# Altium schematic sheet habitcal_front_0.SchDoc
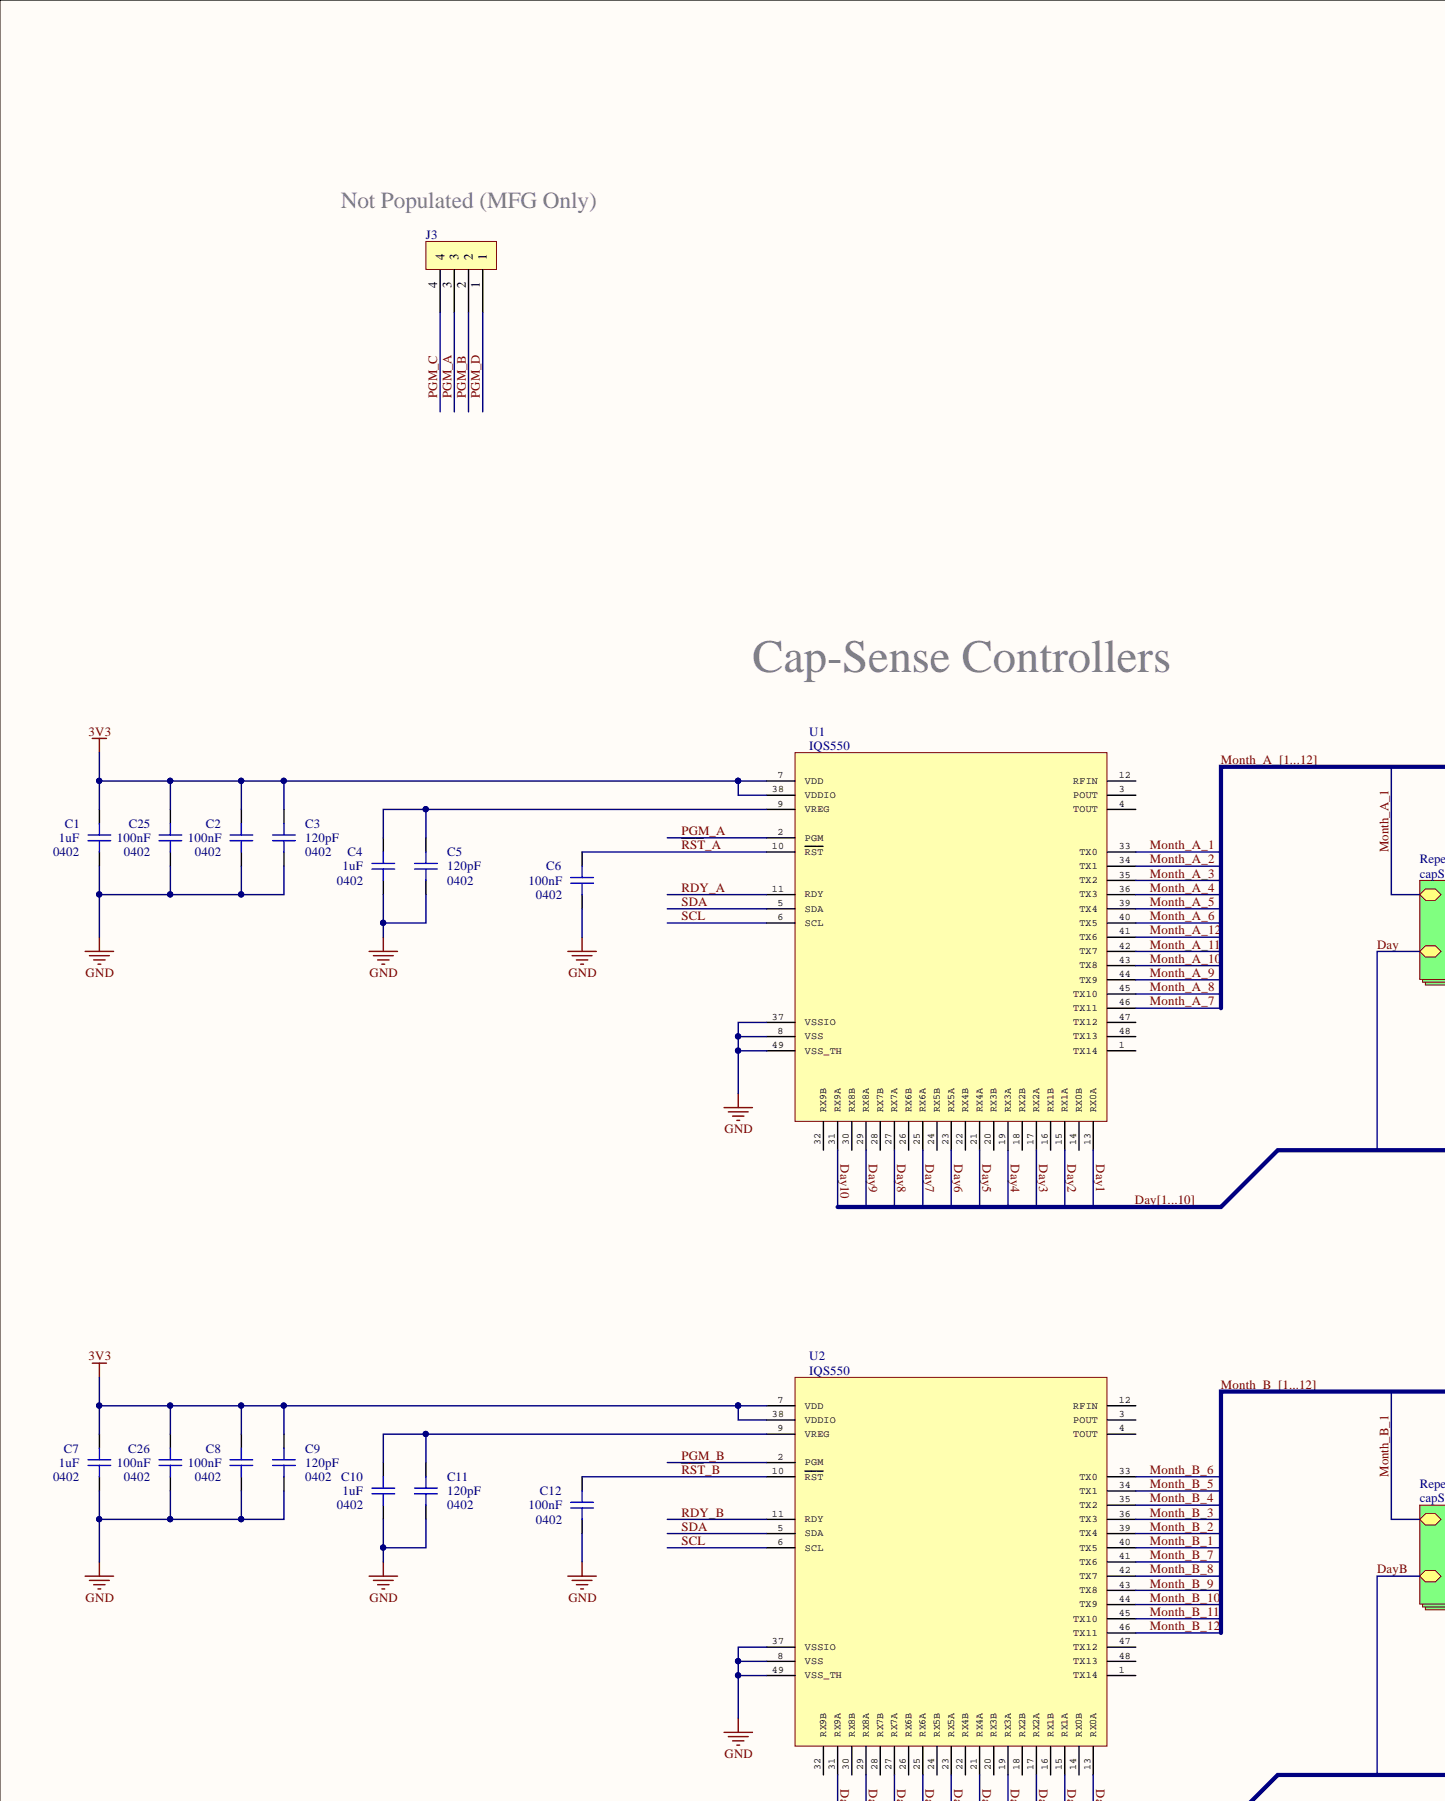
[diagram p0: habitcal_front_0.SchDoc, top left]
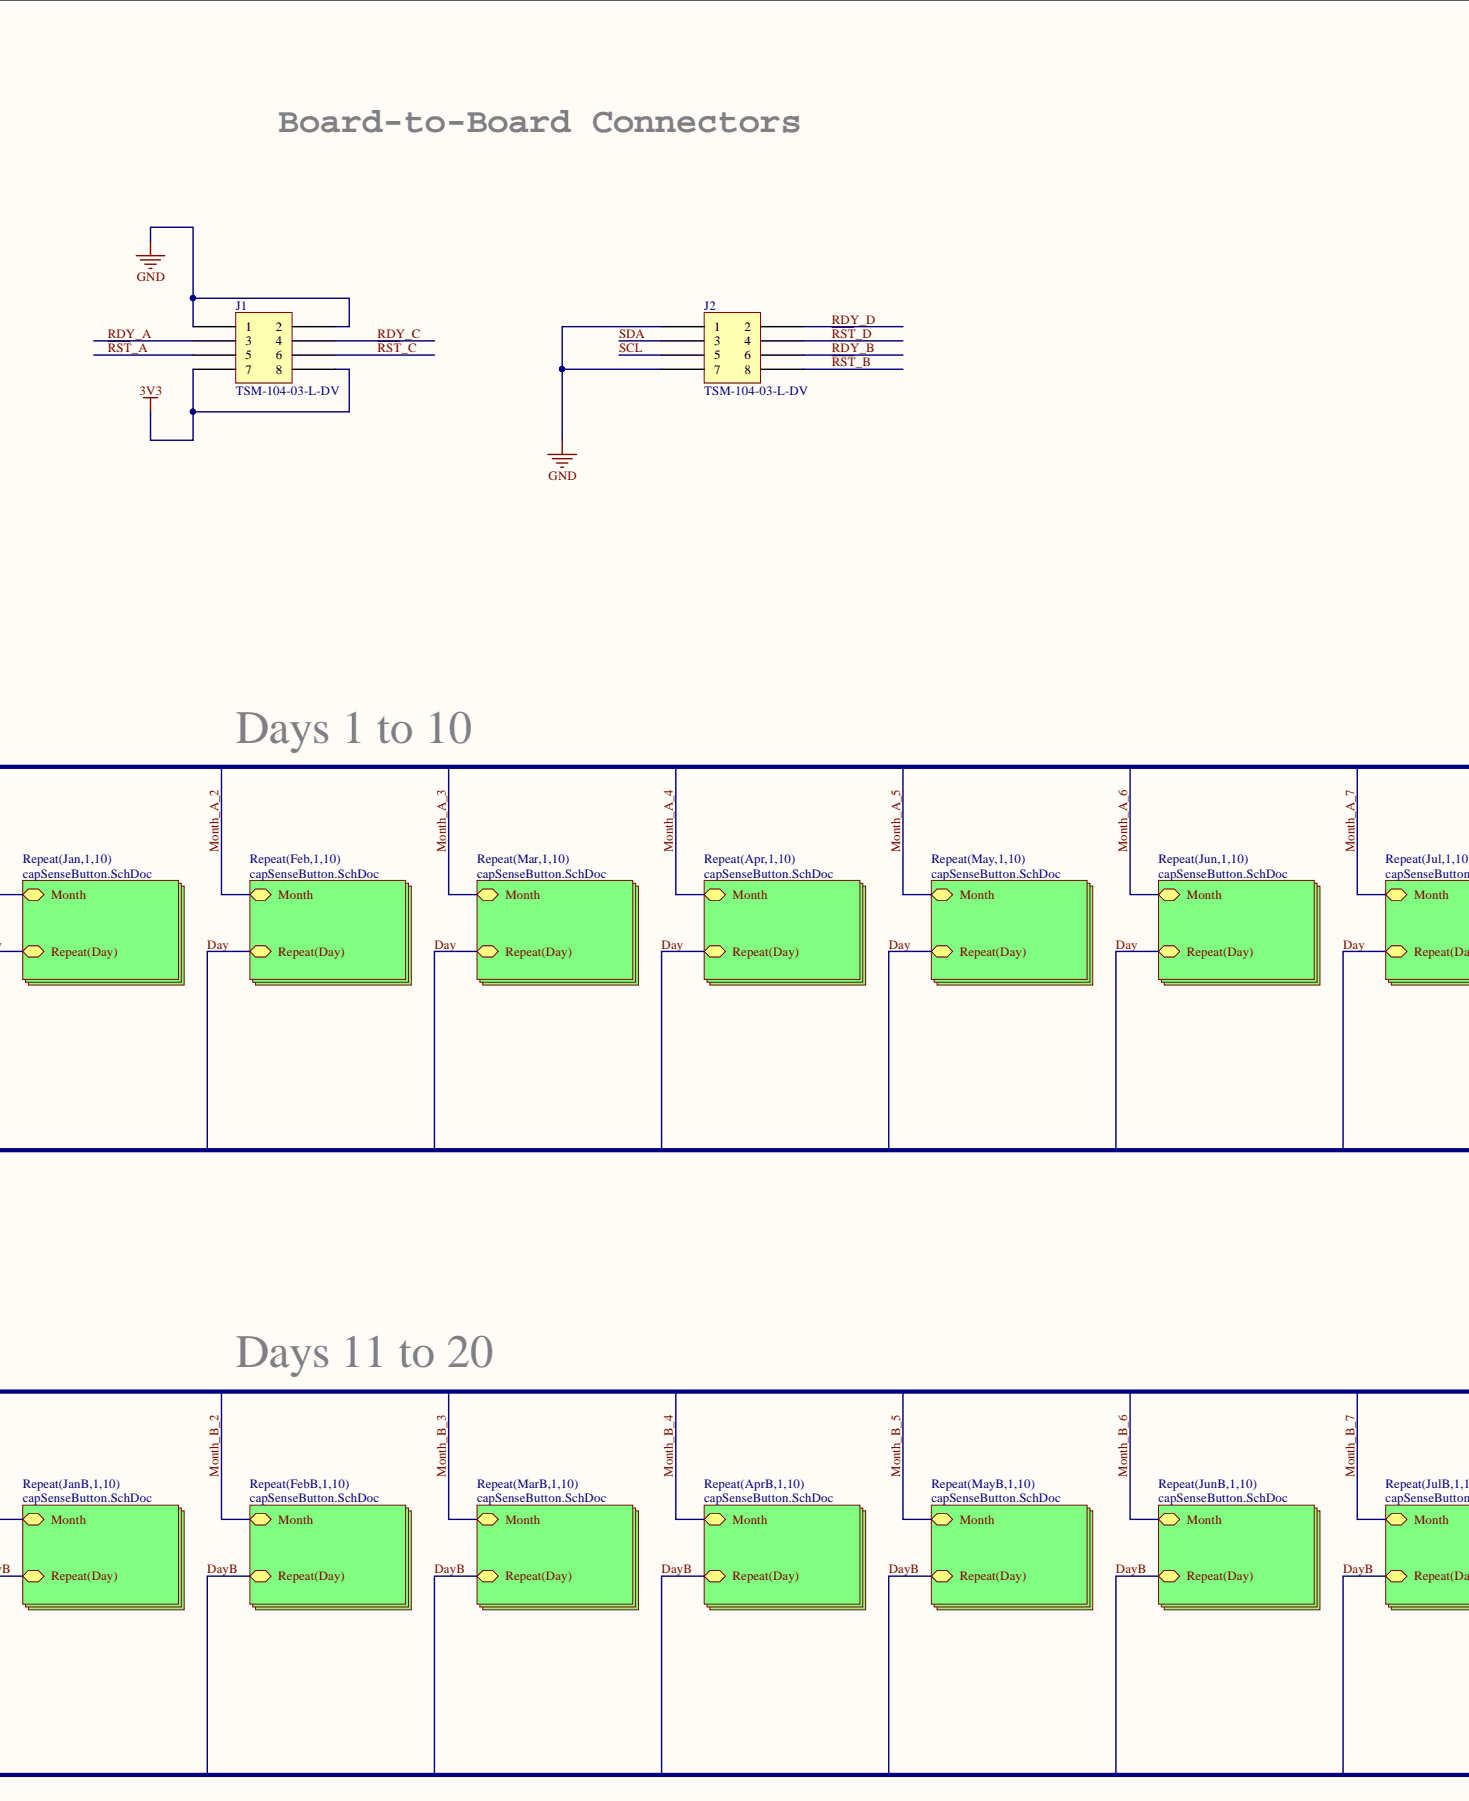
[diagram p1: habitcal_front_0.SchDoc, top centre]
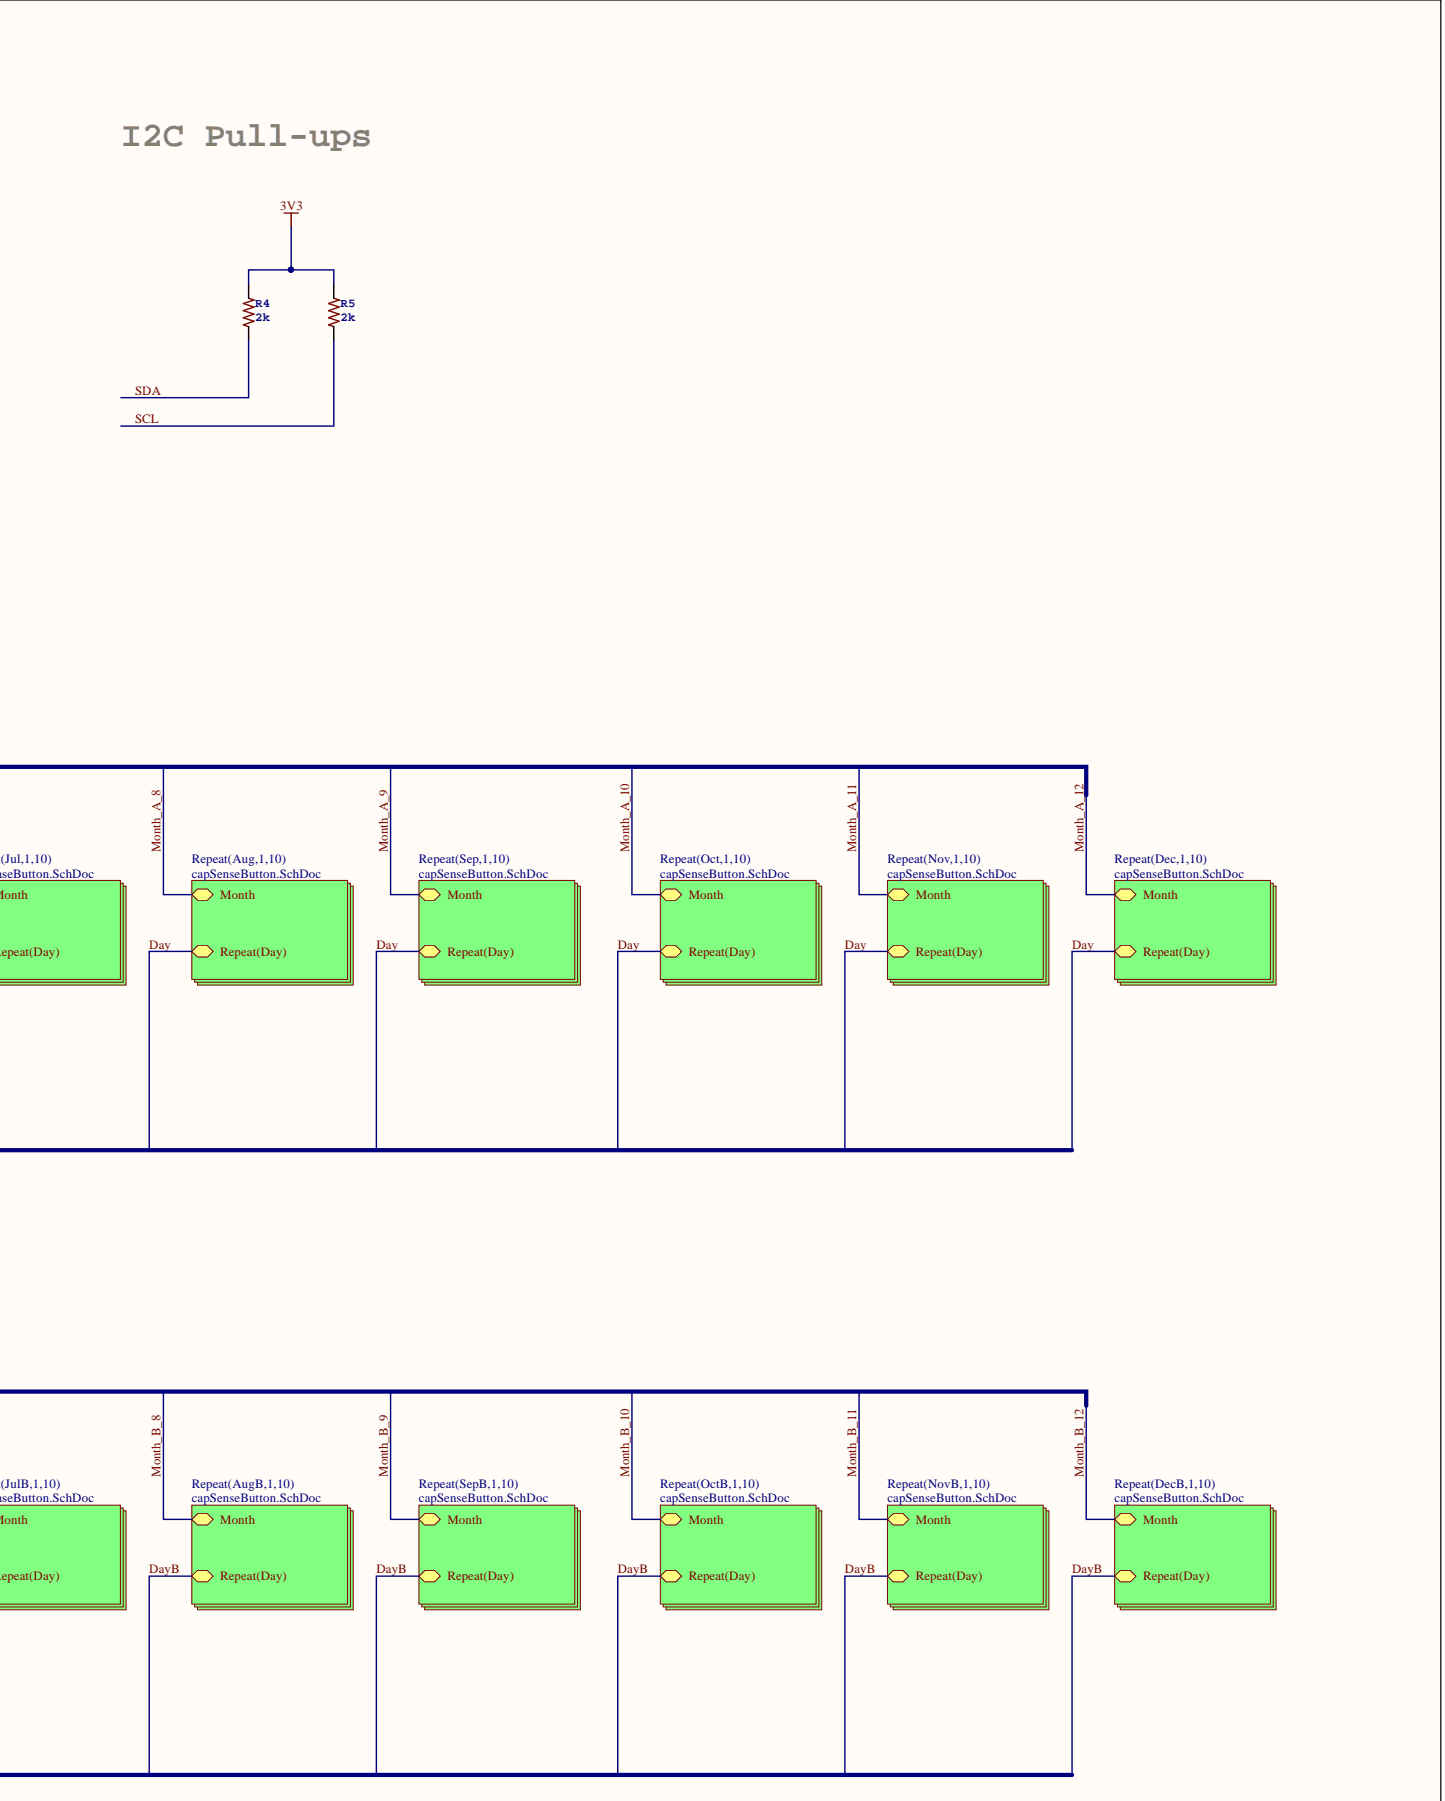
[diagram p2: habitcal_front_0.SchDoc, top right]
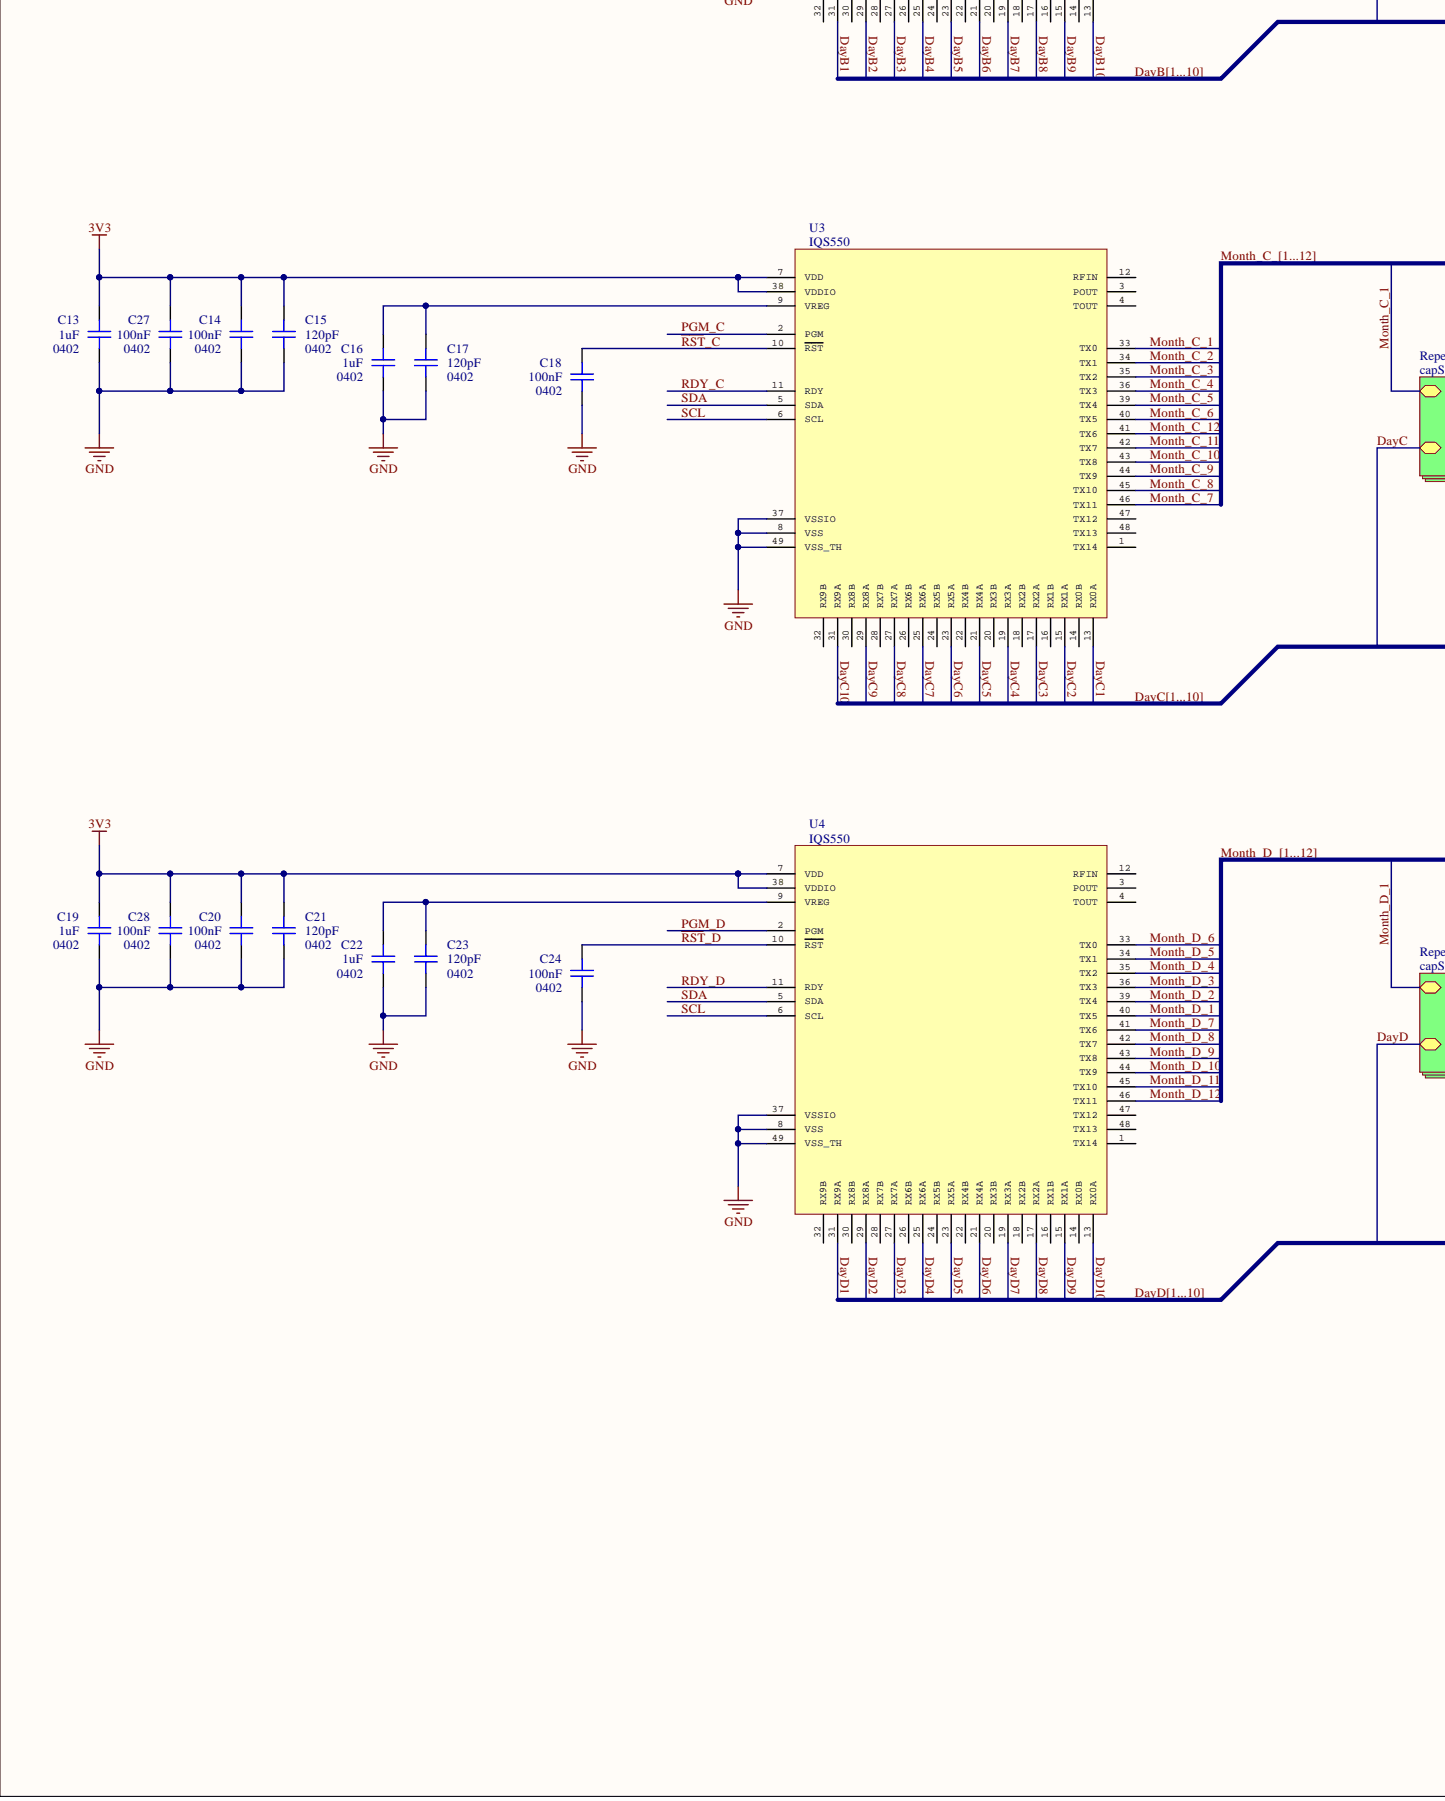
[diagram p3: habitcal_front_0.SchDoc, bottom left]
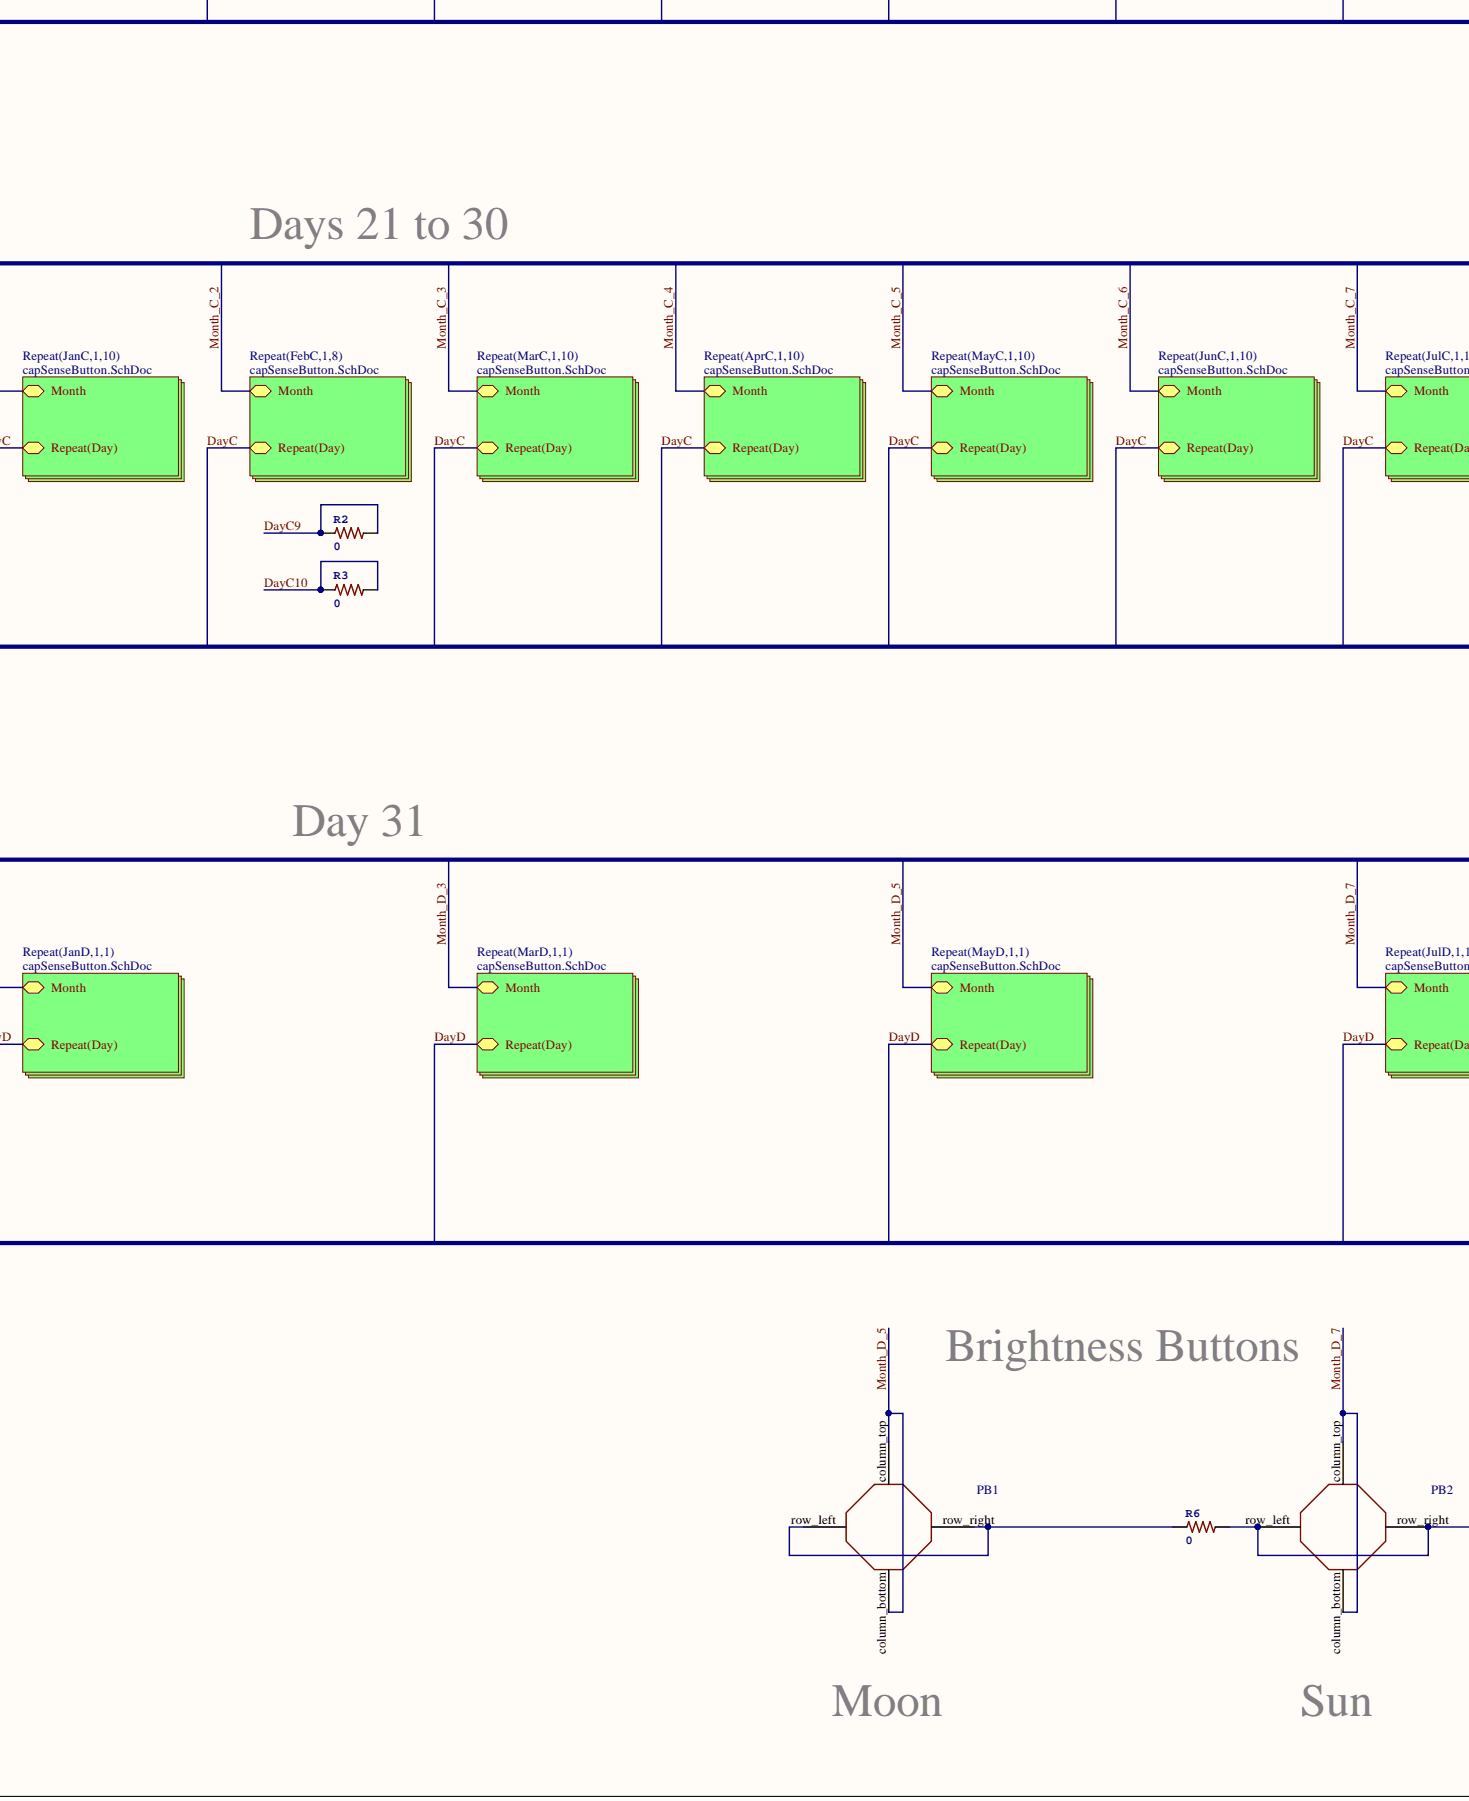
[diagram p4: habitcal_front_0.SchDoc, bottom centre]
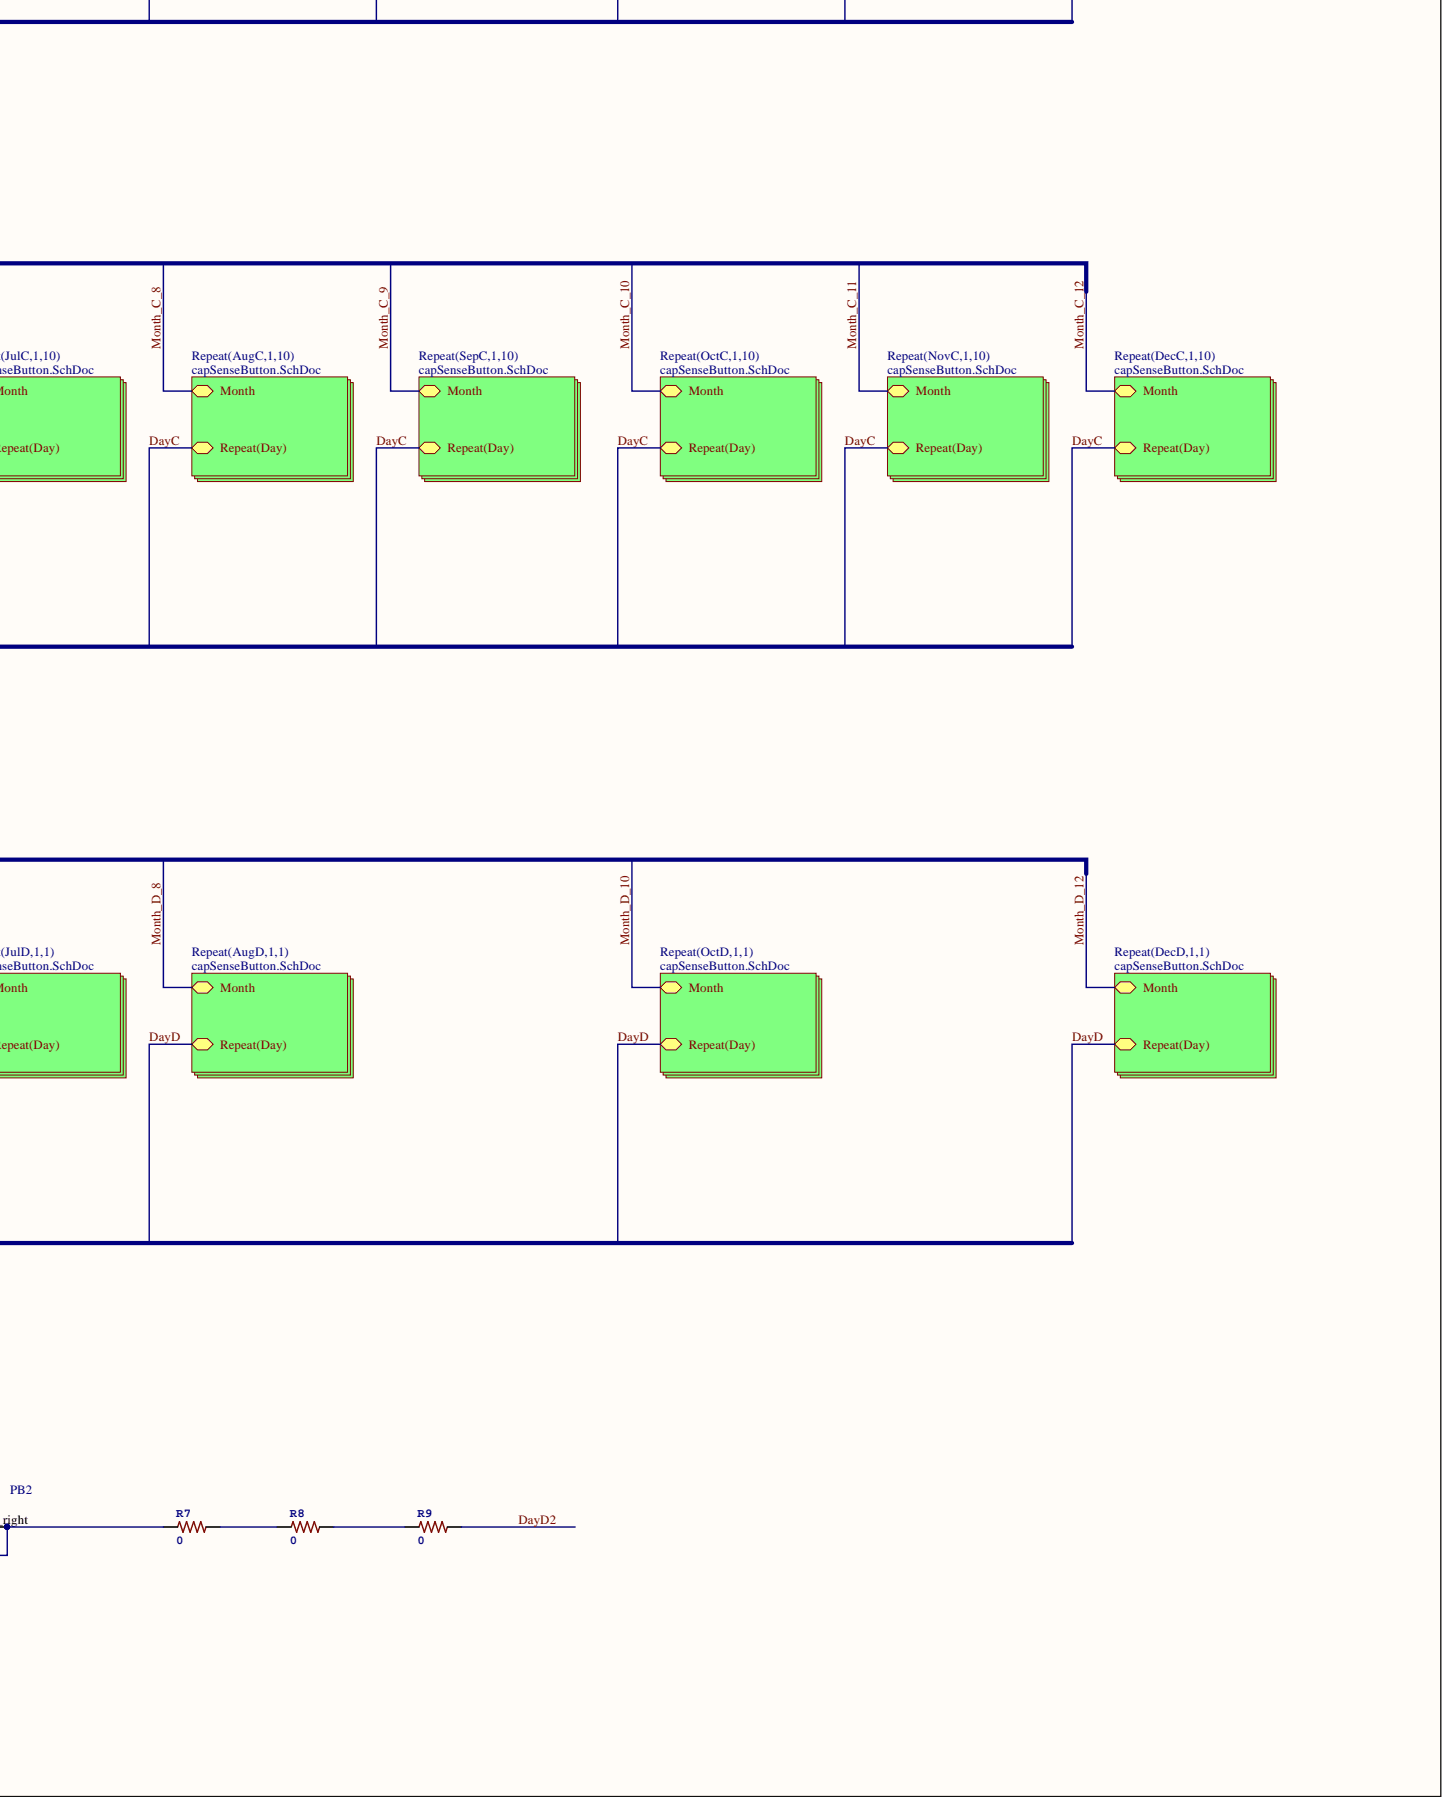
[diagram p5: habitcal_front_0.SchDoc, bottom right]

## Parts
C1  value 1uF  [0402]  (hidden comment: Cap)
C2  value 100nF  [0402]  (hidden comment: Cap)
C3  value 120pF  [0402]  (hidden comment: Cap)
C4  value 1uF  [0402]  (hidden comment: Cap)
C5  value 120pF  [0402]  (hidden comment: Cap)
C6  value 100nF  [0402]  (hidden comment: Cap)
C7  value 1uF  [0402]  (hidden comment: Cap)
C8  value 100nF  [0402]  (hidden comment: Cap)
C9  value 120pF  [0402]  (hidden comment: Cap)
C10  value 1uF  [0402]  (hidden comment: Cap)
C11  value 120pF  [0402]  (hidden comment: Cap)
C12  value 100nF  [0402]  (hidden comment: Cap)
C13  value 1uF  [0402]  (hidden comment: Cap)
C14  value 100nF  [0402]  (hidden comment: Cap)
C15  value 120pF  [0402]  (hidden comment: Cap)
C16  value 1uF  [0402]  (hidden comment: Cap)
C17  value 120pF  [0402]  (hidden comment: Cap)
C18  value 100nF  [0402]  (hidden comment: Cap)
C19  value 1uF  [0402]  (hidden comment: Cap)
C20  value 100nF  [0402]  (hidden comment: Cap)
C21  value 120pF  [0402]  (hidden comment: Cap)
C22  value 1uF  [0402]  (hidden comment: Cap)
C23  value 120pF  [0402]  (hidden comment: Cap)
C24  value 100nF  [0402]  (hidden comment: Cap)
C25  value 100nF  [0402]  (hidden comment: Cap)
C26  value 100nF  [0402]  (hidden comment: Cap)
C27  value 100nF  [0402]  (hidden comment: Cap)
C28  value 100nF  [0402]  (hidden comment: Cap)
J1  TSM-104-03-L-DV  [HEADER_M_4X2_SMD]
J2  TSM-104-03-L-DV  [HEADER_M_4X2_SMD]
J3  TSM-104-01-T-SH_1  [HDR 1x4 - SMD RA]  (value not drawn)
PB1  touchPad  [V5_ALT1_ST_1ST -RAY copperOnly]  (value not drawn)  (no BOM)
PB2  touchPad  [V5_ALT1_ST_1ST -RAY copperOnly]  (value not drawn)  (no BOM)
R2  0  [R0603_0_ohm]  (jumper)
R3  0  [R0603_0_ohm]  (jumper)
R4  2k  [R0603]
R5  2k  [R0603]
R6  0  [R0603_0_ohm]  (jumper)
R7  0  [R0603_0_ohm]  (jumper)
R8  0  [R0603_0_ohm]  (jumper)
R9  0  [R0603_0_ohm]  (jumper)
U1  Part Number: IQS550  [QFN7X7-48]  (hidden comment: IQS550QFN)
U2  Part Number: IQS550  [QFN7X7-48]  (hidden comment: IQS550QFN)
U3  Part Number: IQS550  [QFN7X7-48]  (hidden comment: IQS550QFN)
U4  Part Number: IQS550  [QFN7X7-48]  (hidden comment: IQS550QFN)

## Nets
3V3: C1.2 C2.2 C3.2 C7.2 C8.2 C9.2 C13.2 C14.2 C15.2 C19.2 C20.2 C21.2 C25.2 C26.2 C27.2 C28.2 J1.7 J1.8 R4.2 R5.2 U1.7(VDD) U1.38(VDDIO) U2.7(VDD) U2.38(VDDIO) U3.7(VDD) U3.38(VDDIO) U4.7(VDD) U4.38(VDDIO)
GND: C1.1 C2.1 C3.1 C4.1 C5.1 C6.1 C7.1 C8.1 C9.1 C10.1 C11.1 C12.1 C13.1 C14.1 C15.1 C16.1 C17.1 C18.1 C19.1 C20.1 C21.1 C22.1 C23.1 C24.1 C25.1 C26.1 C27.1 C28.1 J1.1 J1.2 J2.1 J2.7 U1.8(VSS) U1.37(VSSIO) U1.49(VSS_TH) U2.8(VSS) U2.37(VSSIO) U2.49(VSS_TH) U3.8(VSS) U3.37(VSSIO) U3.49(VSS_TH) U4.8(VSS) U4.37(VSSIO) U4.49(VSS_TH)
DAY1: U1.13(RX0A)
DAY2: U1.15(RX1A)
DAY3: U1.17(RX2A)
DAY4: U1.19(RX3A)
DAY5: U1.21(RX4A)
DAY6: U1.23(RX5A)
DAY7: U1.25(RX6A)
DAY8: U1.27(RX7A)
DAY9: U1.29(RX8A)
DAY10: U1.31(RX9A)
DAYB1: U2.31(RX9A)
DAYB2: U2.29(RX8A)
DAYB3: U2.27(RX7A)
DAYB4: U2.25(RX6A)
DAYB5: U2.23(RX5A)
DAYB6: U2.21(RX4A)
DAYB7: U2.19(RX3A)
DAYB8: U2.17(RX2A)
DAYB9: U2.15(RX1A)
DAYB10: U2.13(RX0A)
DAYC1: U3.13(RX0A)
DAYC2: U3.15(RX1A)
DAYC3: U3.17(RX2A)
DAYC4: U3.19(RX3A)
DAYC5: U3.21(RX4A)
DAYC6: U3.23(RX5A)
DAYC7: U3.25(RX6A)
DAYC8: U3.27(RX7A)
DAYC9: R2.1 U3.29(RX8A)
DAYC10: R3.1 U3.31(RX9A)
DAYD1: U4.31(RX9A)
DAYD2: R9.1 U4.29(RX8A)
DAYD3: U4.27(RX7A)
DAYD4: U4.25(RX6A)
DAYD5: U4.23(RX5A)
DAYD6: U4.21(RX4A)
DAYD7: U4.19(RX3A)
DAYD8: U4.17(RX2A)
DAYD9: U4.15(RX1A)
DAYD10: U4.13(RX0A)
MONTH_A_1: U1.33(TX0)
MONTH_A_2: U1.34(TX1)
MONTH_A_3: U1.35(TX2)
MONTH_A_4: U1.36(TX3)
MONTH_A_5: U1.39(TX4)
MONTH_A_6: U1.40(TX5)
MONTH_A_7: U1.46(TX11)
MONTH_A_8: U1.45(TX10)
MONTH_A_9: U1.44(TX9)
MONTH_A_10: U1.43(TX8)
MONTH_A_11: U1.42(TX7)
MONTH_A_12: U1.41(TX6)
MONTH_B_1: U2.40(TX5)
MONTH_B_2: U2.39(TX4)
MONTH_B_3: U2.36(TX3)
MONTH_B_4: U2.35(TX2)
MONTH_B_5: U2.34(TX1)
MONTH_B_6: U2.33(TX0)
MONTH_B_7: U2.41(TX6)
MONTH_B_8: U2.42(TX7)
MONTH_B_9: U2.43(TX8)
MONTH_B_10: U2.44(TX9)
MONTH_B_11: U2.45(TX10)
MONTH_B_12: U2.46(TX11)
MONTH_C_1: U3.33(TX0)
MONTH_C_2: U3.34(TX1)
MONTH_C_3: U3.35(TX2)
MONTH_C_4: U3.36(TX3)
MONTH_C_5: U3.39(TX4)
MONTH_C_6: U3.40(TX5)
MONTH_C_7: U3.46(TX11)
MONTH_C_8: U3.45(TX10)
MONTH_C_9: U3.44(TX9)
MONTH_C_10: U3.43(TX8)
MONTH_C_11: U3.42(TX7)
MONTH_C_12: U3.41(TX6)
MONTH_D_1: U4.40(TX5)
MONTH_D_2: U4.39(TX4)
MONTH_D_3: U4.36(TX3)
MONTH_D_4: U4.35(TX2)
MONTH_D_5: PB1.column_bottom PB1.column_top U4.34(TX1)
MONTH_D_6: U4.33(TX0)
MONTH_D_7: PB2.column_bottom PB2.column_top U4.41(TX6)
MONTH_D_8: U4.42(TX7)
MONTH_D_9: U4.43(TX8)
MONTH_D_10: U4.44(TX9)
MONTH_D_11: U4.45(TX10)
MONTH_D_12: U4.46(TX11)
PGM_A: J3.3 U1.2(PGM)
PGM_B: J3.2 U2.2(PGM)
PGM_C: J3.4 U3.2(PGM)
PGM_D: J3.1 U4.2(PGM)
~{RST}_A: C6.2 J1.5 U1.10(RST)
~{RST}_B: C12.2 J2.8 U2.10(RST)
~{RST}_C: C18.2 J1.6 U3.10(RST)
~{RST}_D: C24.2 J2.4 U4.10(RST)
RDY_A: J1.3 U1.11(RDY)
RDY_B: J2.6 U2.11(RDY)
RDY_C: J1.4 U3.11(RDY)
RDY_D: J2.2 U4.11(RDY)
SCL: J2.5 R5.1 U1.6(SCL) U2.6(SCL) U3.6(SCL) U4.6(SCL)
SDA: J2.3 R4.1 U1.5(SDA) U2.5(SDA) U3.5(SDA) U4.5(SDA)
NetC4_2: C4.2 C5.2 U1.9(VREG)
NetC10_2: C10.2 C11.2 U2.9(VREG)
NetC16_2: C16.2 C17.2 U3.9(VREG)
NetC22_2: C22.2 C23.2 U4.9(VREG)
NetPB1_row_left: PB1.row_left PB1.row_right R6.1
NetPB2_row_left: PB2.row_left PB2.row_right R6.1 R7.1
NetR7_1: R7.1 R8.1
NetR8_1: R8.1 R9.1
NetU1_1: U1.1(TX14)
NetU1_3: U1.3(POUT)
NetU1_4: U1.4(TOUT)
NetU1_12: U1.12(RFIN)
NetU1_14: U1.14(RX0B)
NetU1_16: U1.16(RX1B)
NetU1_18: U1.18(RX2B)
NetU1_20: U1.20(RX3B)
NetU1_22: U1.22(RX4B)
NetU1_24: U1.24(RX5B)
NetU1_26: U1.26(RX6B)
NetU1_28: U1.28(RX7B)
NetU1_30: U1.30(RX8B)
NetU1_32: U1.32(RX9B)
NetU1_47: U1.47(TX12)
NetU1_48: U1.48(TX13)
NetU2_1: U2.1(TX14)
NetU2_3: U2.3(POUT)
NetU2_4: U2.4(TOUT)
NetU2_12: U2.12(RFIN)
NetU2_14: U2.14(RX0B)
NetU2_16: U2.16(RX1B)
NetU2_18: U2.18(RX2B)
NetU2_20: U2.20(RX3B)
NetU2_22: U2.22(RX4B)
NetU2_24: U2.24(RX5B)
NetU2_26: U2.26(RX6B)
NetU2_28: U2.28(RX7B)
NetU2_30: U2.30(RX8B)
NetU2_32: U2.32(RX9B)
NetU2_47: U2.47(TX12)
NetU2_48: U2.48(TX13)
NetU3_1: U3.1(TX14)
NetU3_3: U3.3(POUT)
NetU3_4: U3.4(TOUT)
NetU3_12: U3.12(RFIN)
NetU3_14: U3.14(RX0B)
NetU3_16: U3.16(RX1B)
NetU3_18: U3.18(RX2B)
NetU3_20: U3.20(RX3B)
NetU3_22: U3.22(RX4B)
NetU3_24: U3.24(RX5B)
NetU3_26: U3.26(RX6B)
NetU3_28: U3.28(RX7B)
NetU3_30: U3.30(RX8B)
NetU3_32: U3.32(RX9B)
NetU3_47: U3.47(TX12)
NetU3_48: U3.48(TX13)
NetU4_1: U4.1(TX14)
NetU4_3: U4.3(POUT)
NetU4_4: U4.4(TOUT)
NetU4_12: U4.12(RFIN)
NetU4_14: U4.14(RX0B)
NetU4_16: U4.16(RX1B)
NetU4_18: U4.18(RX2B)
NetU4_20: U4.20(RX3B)
NetU4_22: U4.22(RX4B)
NetU4_24: U4.24(RX5B)
NetU4_26: U4.26(RX6B)
NetU4_28: U4.28(RX7B)
NetU4_30: U4.30(RX8B)
NetU4_32: U4.32(RX9B)
NetU4_47: U4.47(TX12)
NetU4_48: U4.48(TX13)
DAY[1...10]:
DAYB[1...10]:
DAYC[1...10]:
DAYD[1...10]:
MONTH_A_[1...12]:
MONTH_B_[1...12]:
MONTH_C_[1...12]:
MONTH_D_[1...12]:

## Library data of the parts
C1 C4 C7 C10 C13 C16 C19 C22 = Capacitor: Generic Capacitor; Manufacturer: Yageo; PartNumber: CC0603ZRY5V8BB105; Reviewer: RK; Substitute_Allowed: YES; Component Kind: Standard; Library Name: Capacitors.SchLib; Reviewed: 3/17/2017
C2 C6 C8 C12 C14 C18 C20 C24-C28 = Capacitor: Generic Capacitor; Manufacturer: Yageo; PartNumber: CC0603ZRY5V9BB104; Reviewer: RK; Substitute_Allowed: YES; Component Kind: Standard; Library Name: Capacitors.SchLib; Reviewed: 3/17/2017
C3 C5 C9 C11 C15 C17 C21 C23 = Capacitor: Generic Capacitor; Manufacturer: Yageo; PartNumber: CC0402JRNPO9BN121; Reviewer: RK; Substitute_Allowed: YES; Component Kind: Standard; Library Name: Capacitors.SchLib; Reviewed: 3/17/2017
J1 J2 = TSM-104-03-L-DV: 4x2  0.100" SURACE MOUNT TERMINAL STRIPS; Manufacturer: Samtec; Substitute_Allowed: YES; Substitute_Requirement: Height must match; Value: 2x4; Component Kind: Standard; Part Number: TSM-104-03-L-DV; PartNumber: TSM-104-03-L-DV; Library Name: SimonePads.SCHLIB
J3 = TSM-104-01-T-SH_1: CONN HEADER RA SMD 4POS .100" SNGL; Manufacturer: Samtec; Component Kind: Standard; Library Name: Connectors.SchLib; Part Number: TSM-104-01-T-SH; PartNumber: TSM-104-01-T-SH_1
R2 R3 R6-R9 = R_0603_0_ohm: Manufacturer: Yageo; PartNumber: RC0603JR-070RL; Substitute_Allowed: YES; Value: 0; Library Name: SimonePads.SCHLIB
R4 R5 = R_0603: Manufacturer: Yageo; PartNumber: RC0603FR-072KL; Substitute_Allowed: YES; Component Kind: Standard; Value: 2k; Library Name: SimonePads.SCHLIB
U1-U4 = IQS550QFN: 150CH. TRACKPAD/TOUCH SCREEN CON; Manufacturer: Azoteq; PartNumber: IQS550-BL-QNR; Component Kind: Standard; Library Name: SimonePads.SCHLIB
PB1 PB2 = touchPad: Library Name: SimonePads.SCHLIB
